# Altium schematic sheet MODULE INTERFACE.SchDoc
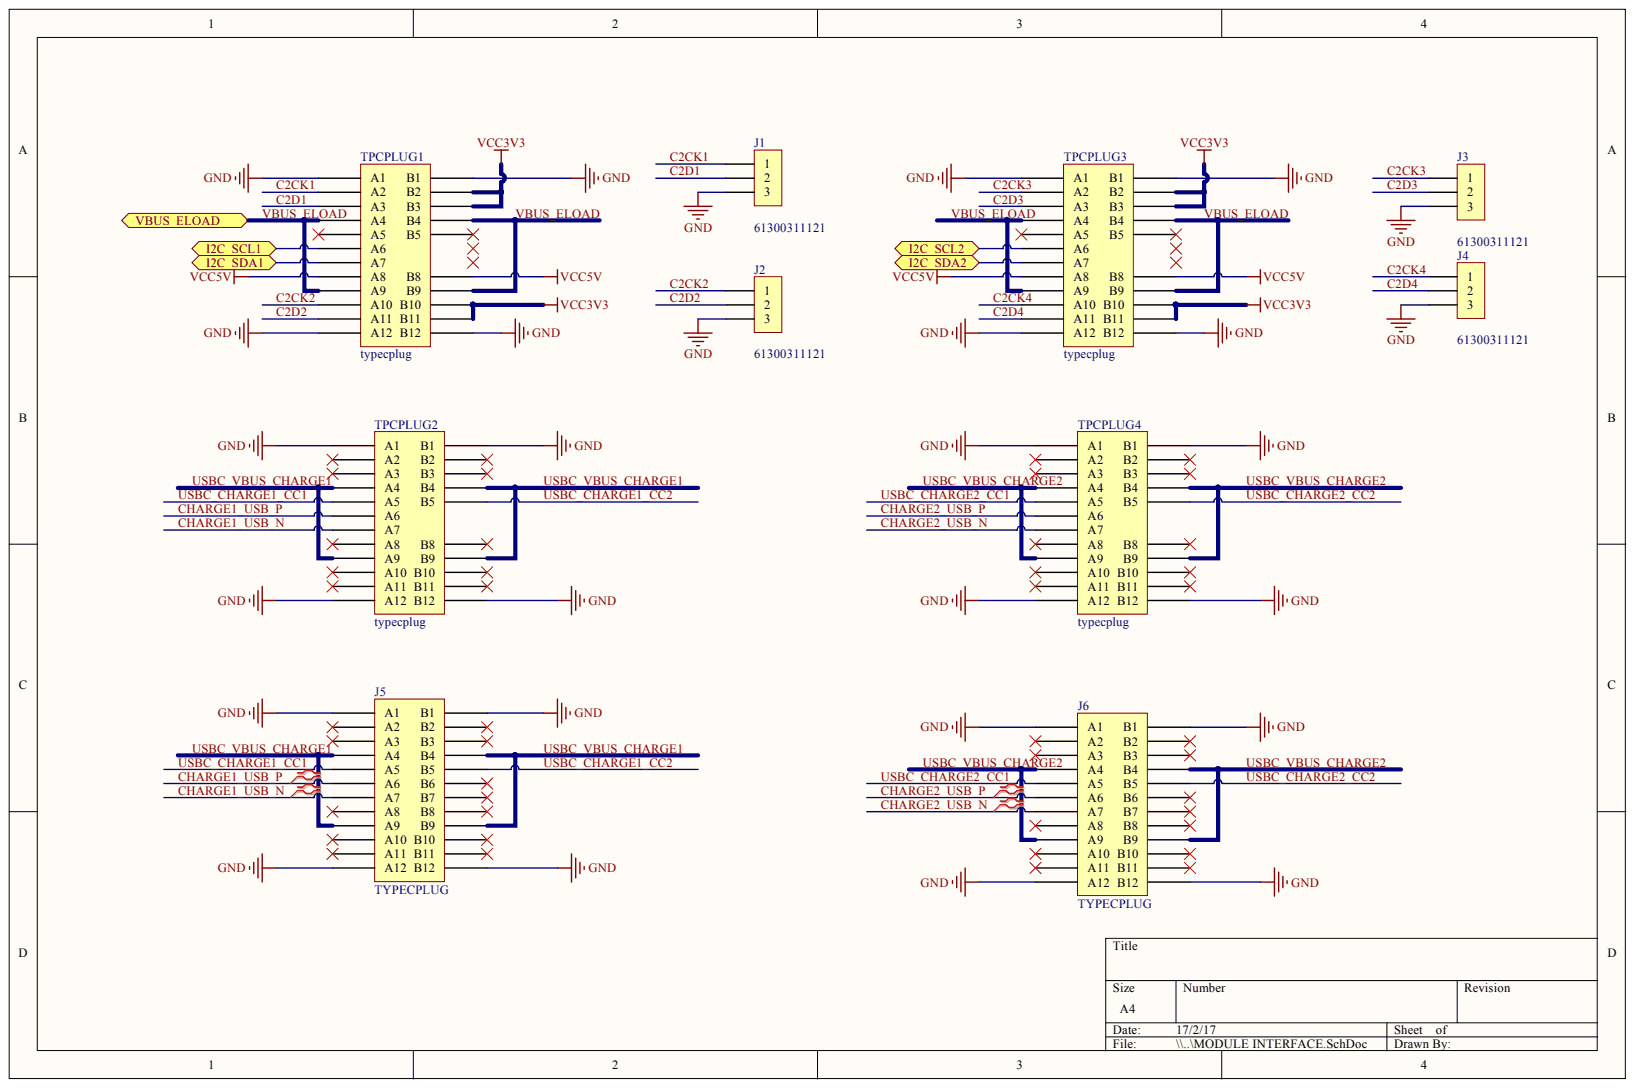
[diagram p0: MODULE INTERFACE.SchDoc]

## Parts
J1  Library Ref: 61300311121  [CON3P-2R54-TH]
J2  Library Ref: 61300311121  [CON3P-2R54-TH]
J3  (no value)  [CON3P-2R54-TH]
J4  Library Ref: 61300311121  [CON3P-2R54-TH]
J5  TYPECPLUG  [TYPE_C_CONNECTOR]
J6  TYPECPLUG  [TYPE_C_CONNECTOR]
TPCPLUG1  typecplug  [TPCPLUG]
TPCPLUG2  typecplug  [TPCPLUG]
TPCPLUG3  typecplug  [TPCPLUG]
TPCPLUG4  typecplug  [TPCPLUG]

## Ports
I2C_SCL1, I2C_SCL2, I2C_SDA1, I2C_SDA2, VBUS_ELOAD

## Nets
GND: J1.3 J2.3 J3.3 J4.3 J5.1(A1) J5.12(A12) J5.13(B1) J5.24(B12) J6.1(A1) J6.12(A12) J6.13(B1) J6.24(B12) TPCPLUG1.A1 TPCPLUG1.A12 TPCPLUG1.B1 TPCPLUG1.B12 TPCPLUG2.A1 TPCPLUG2.A12 TPCPLUG2.B1 TPCPLUG2.B12 TPCPLUG3.A1 TPCPLUG3.A12 TPCPLUG3.B1 TPCPLUG3.B12 TPCPLUG4.A1 TPCPLUG4.A12 TPCPLUG4.B1 TPCPLUG4.B12
VCC3V3: TPCPLUG1.B2 TPCPLUG1.B3 TPCPLUG1.B10 TPCPLUG1.B11 TPCPLUG3.B2 TPCPLUG3.B3 TPCPLUG3.B10 TPCPLUG3.B11
VCC5V: TPCPLUG1.A8 TPCPLUG1.B8 TPCPLUG3.A8 TPCPLUG3.B8
C2CK1: J1.1 TPCPLUG1.A2
C2CK2: J2.1 TPCPLUG1.A10
C2CK3: J3.1 TPCPLUG3.A2
C2CK4: J4.1 TPCPLUG3.A10
C2D1: J1.2 TPCPLUG1.A3
C2D2: J2.2 TPCPLUG1.A11
C2D3: J3.2 TPCPLUG3.A3
C2D4: J4.2 TPCPLUG3.A11
CHARGE1_USB_N: J5.7(A7) TPCPLUG2.A7
CHARGE1_USB_P: J5.6(A6) TPCPLUG2.A6
CHARGE2_USB_N: J6.7(A7) TPCPLUG4.A7
CHARGE2_USB_P: J6.6(A6) TPCPLUG4.A6
I2C_SCL1: TPCPLUG1.A6 ; port I2C_SCL1
I2C_SCL2: TPCPLUG3.A6 ; port I2C_SCL2
I2C_SDA1: TPCPLUG1.A7 ; port I2C_SDA1
I2C_SDA2: TPCPLUG3.A7 ; port I2C_SDA2
USBC_CHARGE1_CC1: J5.5(A5) TPCPLUG2.A5
USBC_CHARGE1_CC2: J5.17(B5) TPCPLUG2.B5
USBC_CHARGE2_CC1: J6.5(A5) TPCPLUG4.A5
USBC_CHARGE2_CC2: J6.17(B5) TPCPLUG4.B5
USBC_VBUS_CHARGE1: J5.4(A4) J5.9(A9) J5.16(B4) J5.21(B9) TPCPLUG2.A4 TPCPLUG2.A9 TPCPLUG2.B4 TPCPLUG2.B9
USBC_VBUS_CHARGE2: J6.4(A4) J6.9(A9) J6.16(B4) J6.21(B9) TPCPLUG4.A4 TPCPLUG4.A9 TPCPLUG4.B4 TPCPLUG4.B9
VBUS_ELOAD: TPCPLUG1.A4 TPCPLUG1.A9 TPCPLUG1.B4 TPCPLUG1.B9 TPCPLUG3.A4 TPCPLUG3.A9 TPCPLUG3.B4 TPCPLUG3.B9 ; port VBUS_ELOAD

Not connected: J5 2(A2) 3(A3) 8(A8) 10(A10) 11(A11) 14(B2) 15(B3) 18(B6) 19(B7) 20(B8) 22(B10) 23(B11); J6 2(A2) 3(A3) 8(A8) 10(A10) 11(A11) 14(B2) 15(B3) 18(B6) 19(B7) 20(B8) 22(B10) 23(B11); TPCPLUG1 A5 B5; TPCPLUG2 A2 A3 A8 A10 A11 B2 B3 B8 B10 B11; TPCPLUG3 A5 B5; TPCPLUG4 A2 A3 A8 A10 A11 B2 B3 B8 B10 B11

## Library data of the parts
J1-J4 = CON3P-2R54-TH-61300311121: DIP,2.54mm,180度,1x3PIN,上针6.0/下针3.0mm,黑色; Allow Altenative Part: Y; Part Number: 102NL-00018BV; Categories: 连接器; Modify time: 2015年07月10号; Category: 单排针; Component Kind: Standard; COMPONENTLINK1DESCRIPTION: Get Datasheet; COMPONENTLINK1URL: http://katalog.we-online.de/en/em/620_0xx_111_21/620_032_111_21?m=n&sp=http%3A%2F%2Fwww.we-online.com%2Fweb%2Fen%2Fwuerth_elektronik%2Fsearchpage.php%3Fsearch%3D62003211121; Footprint Path: 05-Connector\Connector.PcbLib; Footprint Ref: CON3P-2R54-TH; Library Name: Connector.SchLib; Library Path: 05-Connector\Connector.SchLib; MOQ: 500; Mounting Type: Through Hole; PACKAGE: Bulk; Pricing 1: 0.8; Supplier 1: wurth; Supplier Part Number 1: 61300311121
  J3: Library Ref: 61300311121
J5 J6 = TYPECPLUG: Component Kind: Standard; Library Name: USB_ Simulation _Board.SCHLIB
TPCPLUG1-TPCPLUG4 = typecplug: Component Kind: Standard; Library Name: TYPE_C MODULE.SCHLIB
